# Altium schematic sheet usb_ttl_schematic.SchDoc
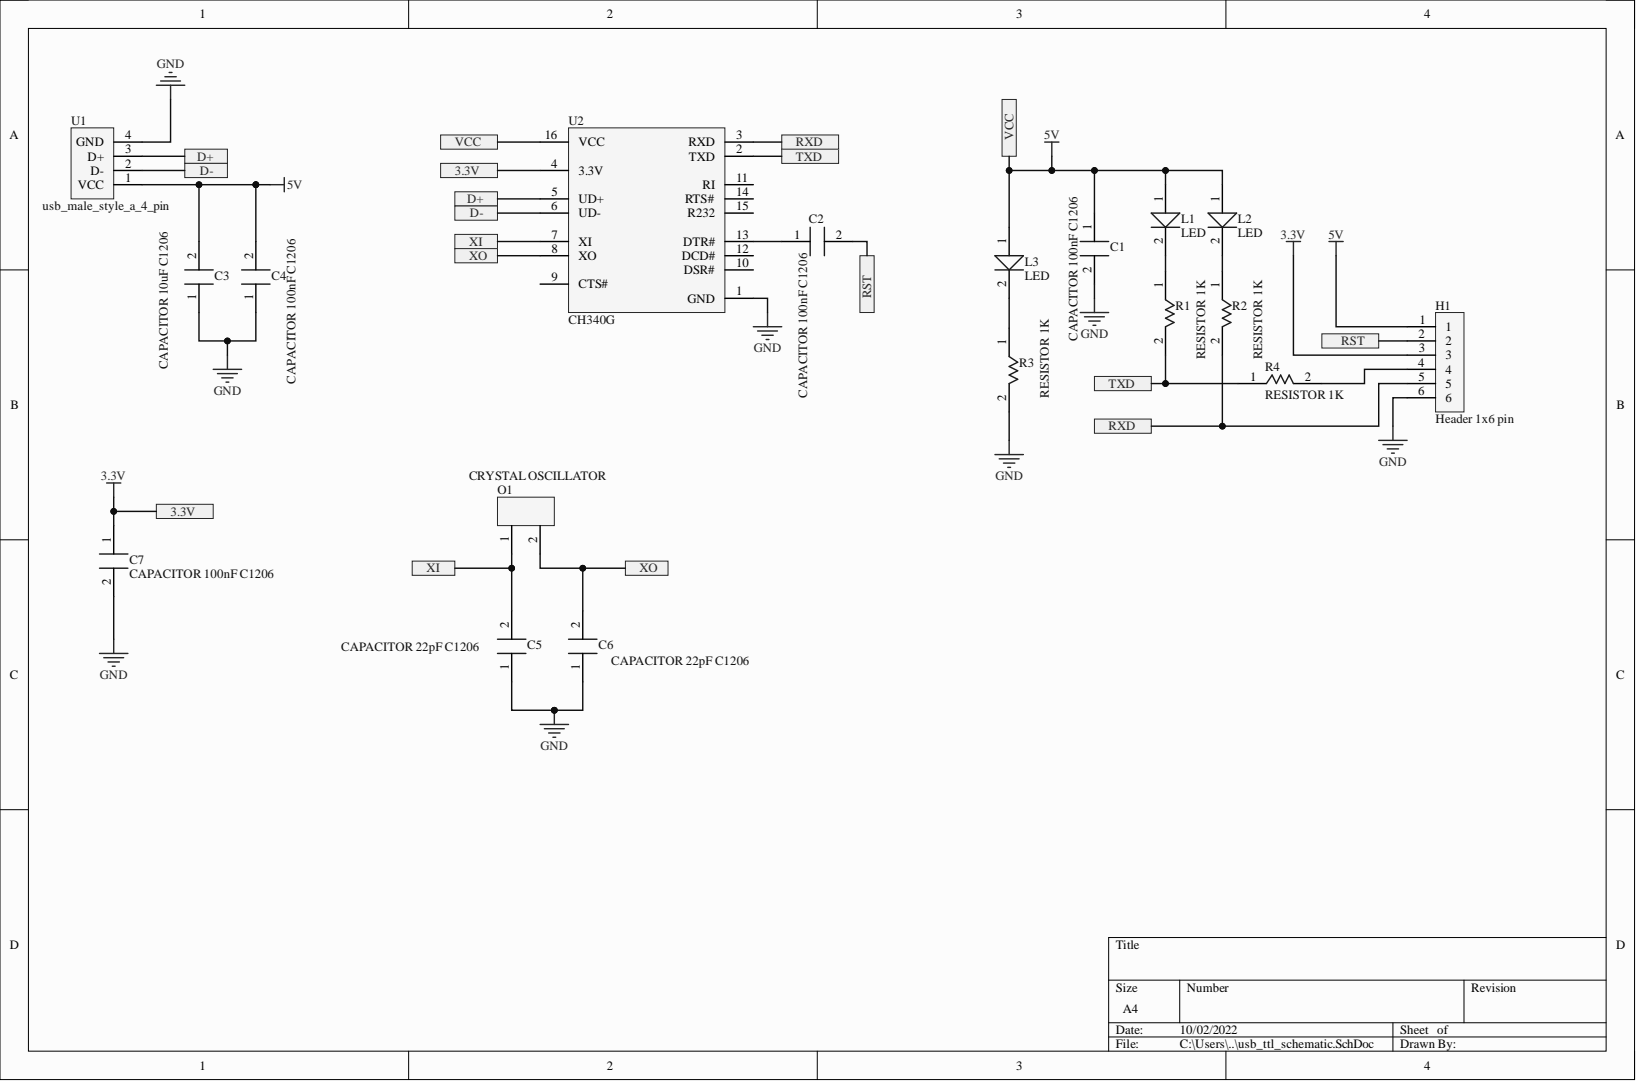
[diagram p0: usb_ttl_schematic.SchDoc]

## Parts
C1  CAPACITOR 100nF C1206  [CAPACITOR C1206]
C2  CAPACITOR 100nF C1206  [CAPACITOR C1206]
C3  CAPACITOR 10uF C1206  [CAPACITOR C1206]
C4  CAPACITOR 100nF C1206  [CAPACITOR C1206]
C5  CAPACITOR 22pF C1206  [CAPACITOR C1206]
C6  CAPACITOR 22pF C1206  [CAPACITOR C1206]
C7  CAPACITOR 100nF C1206  [CAPACITOR C1206]
H1  Header 1x6 pin  [header 1x6]
L1  LED  [led]
L2  LED  [led]
L3  LED  [led]
O1  CRYSTAL OSCILLATOR  [CRYSTAL OSC.]
R1  RESISTOR 1K  [RESISTOR 1K]
R2  RESISTOR 1K  [RESISTOR 1K]
R3  RESISTOR 1K  [RESISTOR 1K]
R4  RESISTOR 1K  [RESISTOR 1K]
U1  usb_male_style_a_4_pin  [usb_style a_male 4_contact]
U2  CH340G  [CH340G]

## Ports
3.3V, D+, D-, RST, RXD, TXD, VCC, XI, XO

## Nets
GND: C1.2 C3.1 C4.1 C5.1 C6.1 C7.2 H1.6 R3.2 U1.4(GND) U2.1(GND)
3.3V: C7.1 H1.3 U2.4(3.3V) ; port 3.3V
5V: C1.1 C3.2 C4.2 H1.1 L1.1 L2.1 L3.1 U1.1(VCC) U2.16(VCC) ; port VCC
RST: C2.2 H1.2 ; port RST
RXD: H1.5 R2.2 U2.3(RXD) ; port RXD
TXD: R1.2 R4.1 U2.2(TXD) ; port TXD
XI: C5.2 O1.1 U2.7(XI) ; port XI
XO: C6.2 O1.2 U2.8(XO) ; port XO
NetC2_1: C2.1 U2.13(DTR#)
NetH1_4: H1.4 R4.2
NetL1_2: L1.2 R1.1
NetL2_2: L2.2 R2.1
NetL3_2: L3.2 R3.1
NetU1_2: U1.2(D-) U2.6(UD-)
NetU1_3: U1.3(D+) U2.5(UD+)

Not connected: U2 9(CTS#) 10(DSR#) 11(RI) 12(DCD#) 14(RTS#) 15(R232)

## Library data of the parts
C1 C2 C4 C7 = CAPACITOR 100nF C1206: CAP 100nF C1206; Component Kind: Standard; Library Name: usb_ttl_schematic_library.SchLib
C3 = CAPACITOR 10uF C1206: CAP 10 uF C1206; Component Kind: Standard; Library Name: usb_ttl_schematic_library.SchLib
C5 C6 = CAPACITOR 22pF C1206: CAP 22pF C1206; Component Kind: Standard; Library Name: usb_ttl_schematic_library.SchLib
H1 = Header 1x6 pin: header 1x6 pim ,2.54 pin gap; Component Kind: Standard; Library Name: usb_ttl_schematic_library.SchLib
O1 = CRYSTAL OSCILLATOR: HC49 ,CRYSTAL OSCILLATOR 12MHz; Component Kind: Standard; Library Name: usb_ttl_schematic_library.SchLib
U1 = usb_male_style_a_4_pin: USB 4 PIN ,STYLE A,MALE; Component Kind: Standard; Library Name: usb_ttl_schematic_library.SchLib
U2 = CH340G: CH320G ,USB_TO_TTL CONVERTER; Component Kind: Standard; Library Name: usb_ttl_schematic_library.SchLib
L1-L3 = LED: Component Kind: Standard; Description: LED; Library Name: usb_ttl_schematic_library.SchLib
R1-R4 = RESISTOR 1K: Component Kind: Standard; Description: RESISTOR 1K; Library Name: usb_ttl_schematic_library.SchLib
